# Altium schematic sheet PWR.SchDoc(PWR)
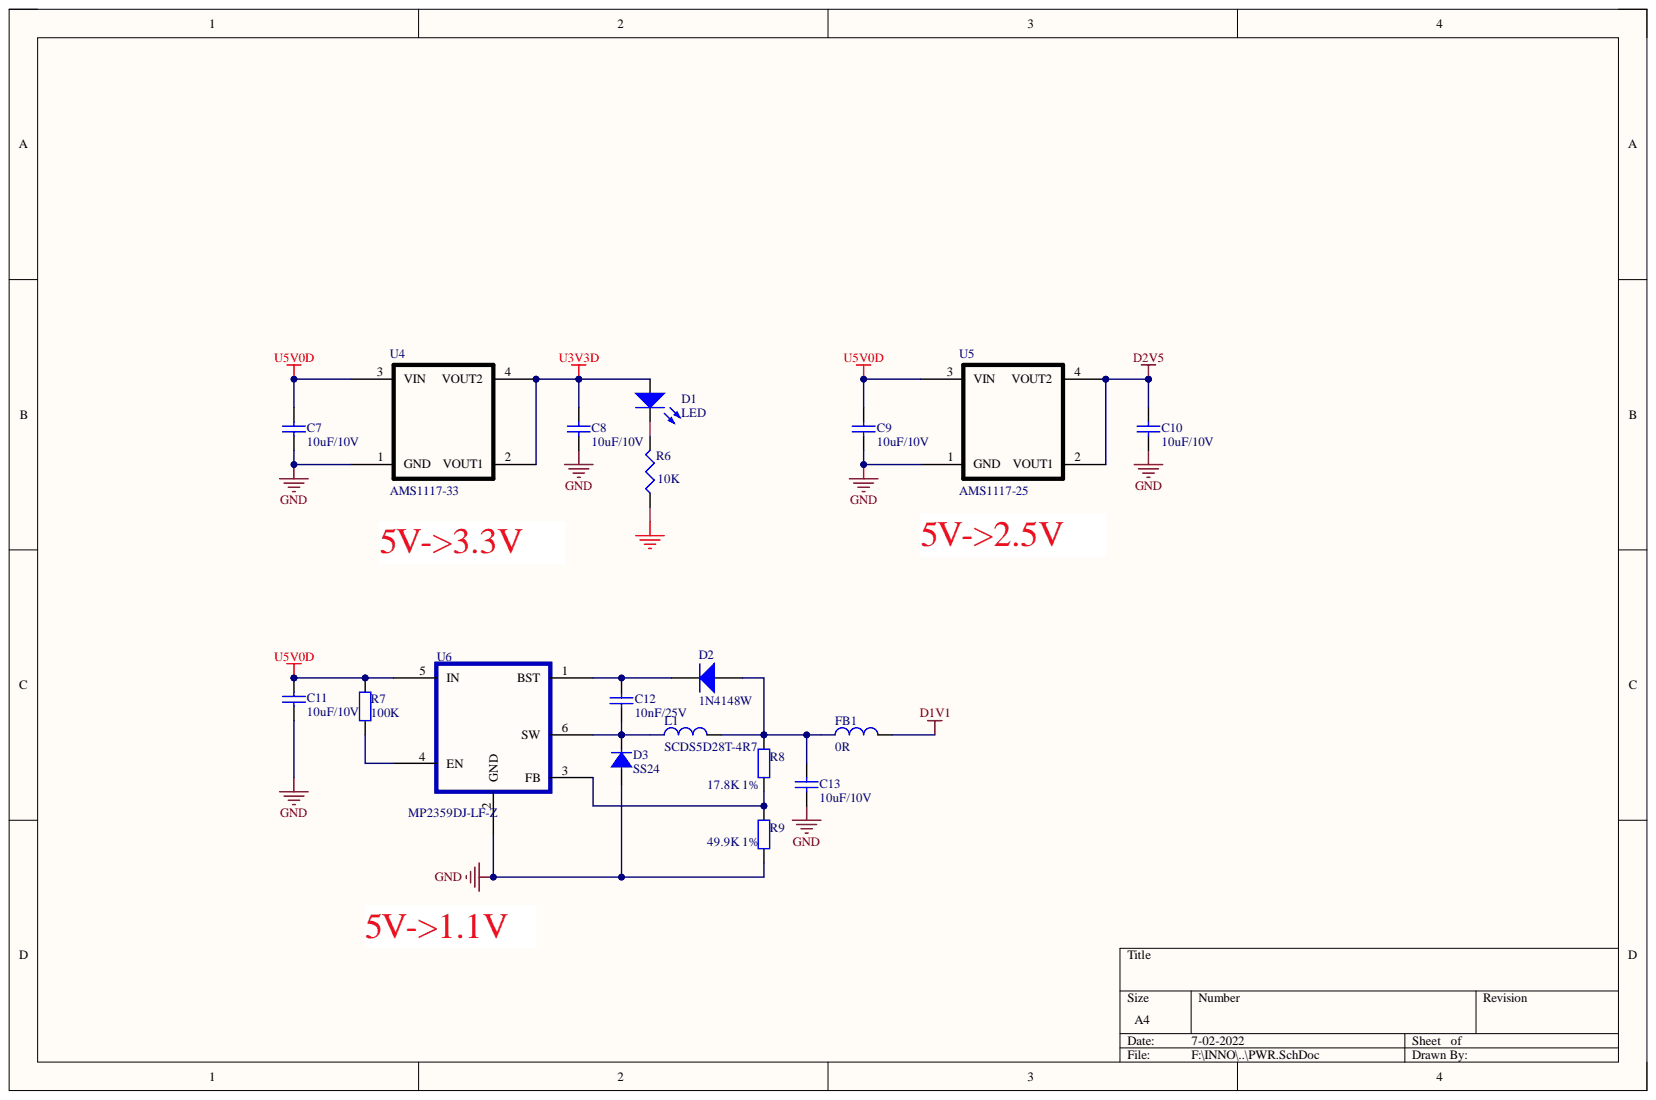
[diagram p0: PWR.SchDoc]

## Parts
C7  10uF/10V  [0805-C]
C8  10uF/10V  [0805-C]
C9  10uF/10V  [0805-C]
C10  10uF/10V  [0805-C]
C11  10uF/10V  [0805-C]
C12  10nF/25V  [0402-C]
C13  10uF/10V  [0805-C]
D1  LED  [0805-LED]
D2  1N4148W  [SOD-123]
D3  SS24  [SMA]
FB1  0R  [0402-R]
L1  SCDS5D28T-4R7  [D63LCB]
R6  10K  [0402-R]
R7  100K  [0402-R]
R8  17.8K 1%  [0402-R]
R9  49.9K 1%  [0402-R]
U4  AMS1117-33  [SOT-223]
U5  AMS1117-25  [SOT-223]
U6  MP2359DJ-LF-Z  [TSOT23-6]

## Nets
D1V1: FB1.1
D2V5: C10.1 U5.2(VOUT1) U5.4(VOUT2)
GND: C7.2 C8.2 C9.2 C10.2 C11.2 C13.2 D3.1 R6.1 R9.1 U4.1(GND) U5.1(GND) U6.2(GND)
U3V3D: C8.1 D1.1 U4.2(VOUT1) U4.4(VOUT2)
U5V0D: C7.1 C9.1 C11.1 R7.2 U4.3(VIN) U5.3(VIN) U6.5(IN)
NetC12_1: C12.1 D2.1 U6.1(BST)
NetC12_2: C12.2 D3.2 L1.2 U6.6(SW)
NetC13_1: C13.1 D2.2 FB1.2 L1.1 R8.2
NetD1_2: D1.2 R6.2
NetR7_1: R7.1 U6.4(EN)
NetR8_1: R8.1 R9.2 U6.3(FB)

## Library data of the parts
C7-C13 = Cap Semi: Capacitor (Semiconductor SIM Model); Component Kind: Standard; Library Name: ctr_prj.SchLib
D2 = M1: 整流二极管; Component Kind: Standard; Library Name: ctr_prj.SchLib
D3 = DIODE: Component Kind: Standard; Library Name: ctr_prj.SchLib
FB1 = L: 磁珠; Component Kind: Standard; Library Name: ctr_prj.SchLib
L1 = L: 电感; Component Kind: Standard; Library Name: ctr_prj.SchLib
R6 = Res: Resistor; Component Kind: Standard; Library Name: Schlib.SchLib
R7-R9 = Res2: Resistor; Component Kind: Standard; Library Name: ctr_prj.SchLib
U4 U5 = LD1117AS33: LDO电源芯片; Component Kind: Standard; Library Name: ctr_prj.SchLib
U6 = MP2359: 电源IC; Component Kind: Standard; Library Name: ctr_prj.SchLib
D1 = LED: Component Kind: Standard; Description: LED; Library Name: Schlib.SchLib
